# Altium schematic sheet 02-Launchpad.SchDoc
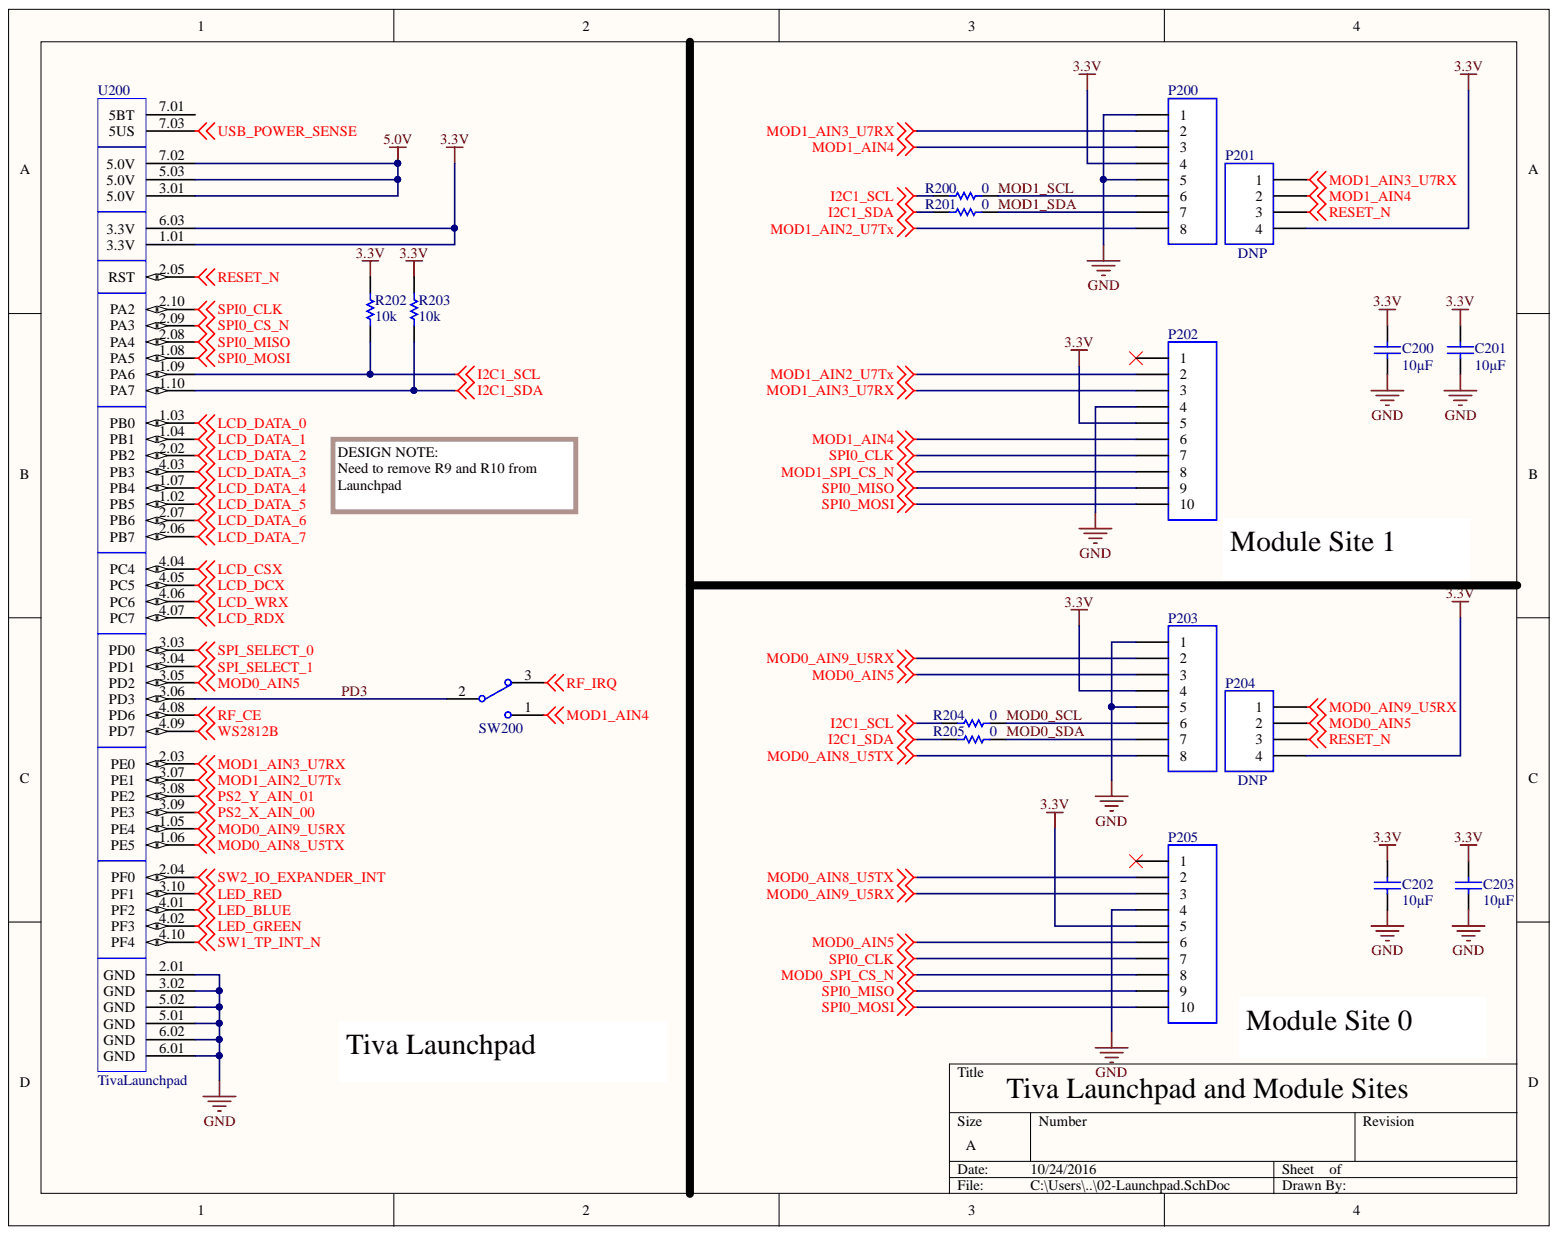
[diagram p0: 02-Launchpad.SchDoc]

## Parts
C200  10µF  [0805]
C201  10µF  [0805]
C202  10µF  [0805]
C203  10µF  [0805]
P200  Header 8  [HDR1X8]  (value not drawn)
P201  Usage: DNP  [HDR1X4]  (hidden comment: Socket-4)
P202  Header 10  [HDR1X10]  (value not drawn)
P203  Header 8  [HDR1X8]  (value not drawn)
P204  Usage: DNP  [HDR1X4]  (hidden comment: Socket-4)
P205  Header 10  [HDR1X10]  (value not drawn)
R200  0  [RES_0805]
R201  0  [RES_0805]
R202  10k  [RES_0805]
R203  10k  [RES_0805]
R204  0  [RES_0805]
R205  0  [RES_0805]
SW200  SWITCH-SPDT  [SWITCH_OS102011MS2QN1]  (value not drawn)
U200  TivaLaunchpad  [TivaLaunchPad]

## Nets
3.3V: C200.2 C201.2 C202.2 C203.2 P200.4 P201.4 P202.5 P203.4 P204.4 P205.5 R202.2 R203.2 U200.1.01(3.3V) U200.6.03(3.3V)
5.0V: U200.3.01(5.0V) U200.5.03(5.0V) U200.7.02(5.0V)
GND: C200.1 C201.1 C202.1 C203.1 P200.1 P200.5 P202.4 P203.1 P203.5 P205.4 U200.2.01(GND) U200.3.02(GND) U200.5.01(GND) U200.5.02(GND) U200.6.01(GND) U200.6.02(GND)
I2C1_SCL: R200.2 R202.1 R204.2 U200.1.09(PA6)
I2C1_SDA: R201.2 R203.1 R205.2 U200.1.10(PA7)
LCD_CSX: U200.4.04(PC4)
LCD_DATA_0: U200.1.03(PB0)
LCD_DATA_1: U200.1.04(PB1)
LCD_DATA_2: U200.2.02(PB2)
LCD_DATA_3: U200.4.03(PB3)
LCD_DATA_4: U200.1.07(PB4)
LCD_DATA_5: U200.1.02(PB5)
LCD_DATA_6: U200.2.07(PB6)
LCD_DATA_7: U200.2.06(PB7)
LCD_DCX: U200.4.05(PC5)
LCD_RDX: U200.4.07(PC7)
LCD_WRX: U200.4.06(PC6)
LED_BLUE: U200.4.01(PF2)
LED_GREEN: U200.4.02(PF3)
LED_RED: U200.3.10(PF1)
MOD0_AIN5: P203.3 P204.2 P205.6 U200.3.05(PD2)
MOD0_AIN8_U5TX: P203.8 P205.2 U200.1.06(PE5)
MOD0_AIN9_U5RX: P203.2 P204.1 P205.3 U200.1.05(PE4)
MOD0_SPI_CS_N: P205.8
MOD1_AIN2_U7TX: P200.8 P202.2 U200.3.07(PE1)
MOD1_AIN3_U7RX: P200.2 P201.1 P202.3 U200.2.03(PE0)
MOD1_AIN4: P200.3 P201.2 P202.6 SW200.1
MOD1_SPI_CS_N: P202.8
PS2_X_AIN_00: U200.3.09(PE3)
PS2_Y_AIN_01: U200.3.08(PE2)
RESET_N: P201.3 P204.3 U200.2.05(RST)
RF_CE: U200.4.08(PD6)
RF_IRQ: SW200.3
SPI0_CLK: P202.7 P205.7 U200.2.10(PA2)
SPI0_CS_N: U200.2.09(PA3)
SPI0_MISO: P202.9 P205.9 U200.2.08(PA4)
SPI0_MOSI: P202.10 P205.10 U200.1.08(PA5)
SPI_SELECT_0: U200.3.03(PD0)
SPI_SELECT_1: U200.3.04(PD1)
SW1_TP_INT_N: U200.4.10(PF4)
SW2_IO_EXPANDER_INT: U200.2.04(PF0)
USB_POWER_SENSE: U200.7.03(5US)
WS2812B: U200.4.09(PD7)
MOD0_SCL: P203.6 R204.1
MOD0_SDA: P203.7 R205.1
MOD1_SCL: P200.6 R200.1
MOD1_SDA: P200.7 R201.1
PD3: SW200.2 U200.3.06(PD3)

Not connected: P202 1; P205 1; U200 7.01(5BT)

## Library data of the parts
C200-C203 = CAP_0805_U_010.000: CAP CER 10UF 16V 10% X5R 0805; Manufacturer: Murata Electronics North America; Manufacturer Part Number: GRM21BR61C106KE15L; Applications: General Purpose; Capacitance: 10µF; Category: Capacitors; Component Kind: Standard; Features: -; Height - Seated (Max): -; Lead Spacing: -; Lead Style: -; Library Name: ece353-2016.SchLib; Mounting Type: Surface Mount, MLCC; Operating Temperature: -55°C ~ 85°C; Package / Case: 0805 (2012 Metric); Packaging: Cut Tape (CT); Ratings: -; RoHS: RoHS Compliant; Size / Dimension: 0.079" L x 0.049" W (2.00mm x 1.25mm); Supplier: Digi-Key; Supplier 1: Digi-Key; Supplier Part Number: 490-3886-1-ND; Supplier Part Number 1: 490-3886-1-ND; Temperature Coefficient: X5R; Thickness (Max): 0.053" (1.35mm); Tolerance: ±10%; Value: 10µF; Voltage - Rated: 16V
P200 P203 = Header 8: Header, 8-Pin; Manufacturer: Sullins Connector Solutions; Manufacturer Part Number: PPTC081LFBN-RC; Number of Positions: 8; Number of Positions Loaded: All; Pitch: 0.100" (2.54mm); Category: Connectors, Interconnects; Color: Black; Component Kind: Standard; Connector Type: Header; Contact Finish: Tin; Contact Finish Thickness: -; Contact Type: Female Socket; Fastening Type: -; Features: -; LatestRevisionDate: 17-Jul-2002; LatestRevisionNote: Re-released for DXP Platform.; Library Name: ece353-2016.SchLib; Mounting Type: Through Hole; Number of Rows: 1; Packaging: Bulk; RoHS: RoHS Compliant; Row Spacing: -; Supplier: Digi-Key; Supplier 1: Digi-Key; Supplier Part Number: S7006-ND; Supplier Part Number 1: S7006-ND; Termination: Solder; Publisher: Altium Limited
P201 P204 = Socket-4: Socket, 4-Pin; Manufacturer: Sullins Connector Solutions; Manufacturer Part Number: PPTC041LFBN-RC; Connector Type: Header; Number of Positions: 4; Number of Positions Loaded: All; Pitch: 0.100" (2.54mm); Category: Connectors, Interconnects; Color: Black; Component Kind: Standard; Contact Finish: Tin; Contact Finish Thickness: -; Contact Type: Female Socket; Fastening Type: -; Features: -; Library Name: ece353-2016.SchLib; Mounting Type: Through Hole; Number of Rows: 1; Packaging: Bulk; RoHS: RoHS Compliant; Row Spacing: -; Supplier: Digi-Key; Supplier 1: Digi-Key; Supplier Part Number: S7002-ND; Supplier Part Number 1: S7002-ND; Termination: Solder
P202 P205 = Header 10: Header, 10-Pin; Manufacturer: Sullins Connector Solutions; Manufacturer Part Number: PPTC101LFBN-RC; Number of Positions: 10; Number of Positions Loaded: All; Pitch: 0.100" (2.54mm); Category: Connectors, Interconnects; Color: Black; Component Kind: Standard; Connector Type: Header; Contact Finish: Tin; Contact Finish Thickness: -; Contact Type: Female Socket; Fastening Type: -; Features: -; LatestRevisionDate: 17-Jul-2002; LatestRevisionNote: Re-released for DXP Platform.; Library Name: ece353-2016.SchLib; Mounting Type: Through Hole; Number of Rows: 1; Packaging: Bulk; RoHS: RoHS Compliant; Row Spacing: -; Supplier: Digi-Key; Supplier 1: Digi-Key; Supplier Part Number: S7008-ND; Supplier Part Number 1: S7008-ND; Termination: Solder; Publisher: Altium Limited
R200 R201 R204 R205 = RES_0805_000.00: RES 0, , 1%, 0.125W, 1/8W 0805; Manufacturer: Panasonic Electronic Components; Manufacturer Part Number: ERJ-6GEY0R00V; Tolerance: Jumper; Category: Resistors; Component Kind: Standard; Composition: Thick Film; Features: Automotive AEC-Q200; Height: 0.028" (0.70mm); Library Name: ece353-2016.SchLib; Number of Terminations: 2; Operating Temperature: -55°C ~ 155°C; Package / Case: 0805 (2012 Metric); Packaging: Cut Tape (CT); Power (Watts): 0.125W, 1/8W; Resistance: 0; RoHS: RoHS Compliant; Size / Dimension: 0.079" L x 0.049" W (2.00mm x 1.25mm); Supplier: Digi-Key; Supplier 1: Digi-Key; Supplier Device Package: 0805; Supplier Part Number: P0.0ACT-ND; Supplier Part Number 1: P0.0ACT-ND; Temperature Coefficient: -; Value: 0
R202 R203 = RES_0805_K_010.00: RES 10K 1/10W 1% 0805 SMD; Manufacturer: Panasonic Electronic Components; Manufacturer Part Number: ERJ-6ENF1002V; Power (Watts): 0.125W, 1/8W; Temperature Coefficient: ±100ppm/°C; Category: Resistors; Component Kind: Standard; Composition: Thick Film; Features: Automotive AEC-Q200; Height: 0.028" (0.70mm); Library Name: ece353-2016.SchLib; Number of Terminations: 2; Operating Temperature: -55°C ~ 155°C; Package / Case: 0805 (2012 Metric); Packaging: Cut Tape (CT); Resistance: 10k; RoHS: RoHS Compliant; Size / Dimension: 0.079" L x 0.049" W (2.00mm x 1.25mm); Supplier: Digi-Key; Supplier 1: Digi-Key; Supplier Device Package: 0805; Supplier Part Number: P10.0KCCT-ND; Supplier Part Number 1: P10.0KCCT-ND; Tolerance: ±1%; Value: 10k
SW200 = SWITCH-SPDT: SPDT Subminiature Toggle Switch, Right Angle Mounting, Vertical Actuation; Manufacturer: C&K Components; Manufacturer Part Number: OS102011MS2QN1; Actuator Length: 4.00mm; Actuator Material: Polyoxymethylene (Acetal) (POM); Actuator Type: Standard; Contact Timing: Non-Shorting (BBM); Current Rating: 100mA (DC); Electrical Life: 10,000 Cycles; Switch Function: On-On; Switch Travel: 2.00mm; Voltage Rating - DC: 12V; Category: Switches; Circuit: SPDT; Component Kind: Standard; Contact Finish: Silver; Contact Material: Copper Alloy; Features: Epoxy Sealed Terminals; Library Name: ece353-2016.SchLib; Mechanical Life: 10,000 Cycles; Mounting Type: Through Hole; Operating Force: -; Operating Temperature: -30°C ~ 70°C; Packaging: Bulk; RoHS: RoHS Compliant; Supplier: Digi-Key; Supplier 1: Digi-Key; Supplier Part Number: CKN9565-ND; Supplier Part Number 1: CKN9565-ND; Termination Style: PC Pin; Voltage Rating - AC: -
U200 = TivaLaunchpad: Manufacturer Part Number: EK-TM4C123GXL; Usage: DNP; Component Kind: Standard; Description: TivaLaunchpad; Library Name: ece353-2016.SchLib; Supplier 1: Digi-Key; Supplier Part Number 1: 296-35760-ND
